# Altium schematic sheet transmitter.SchDoc
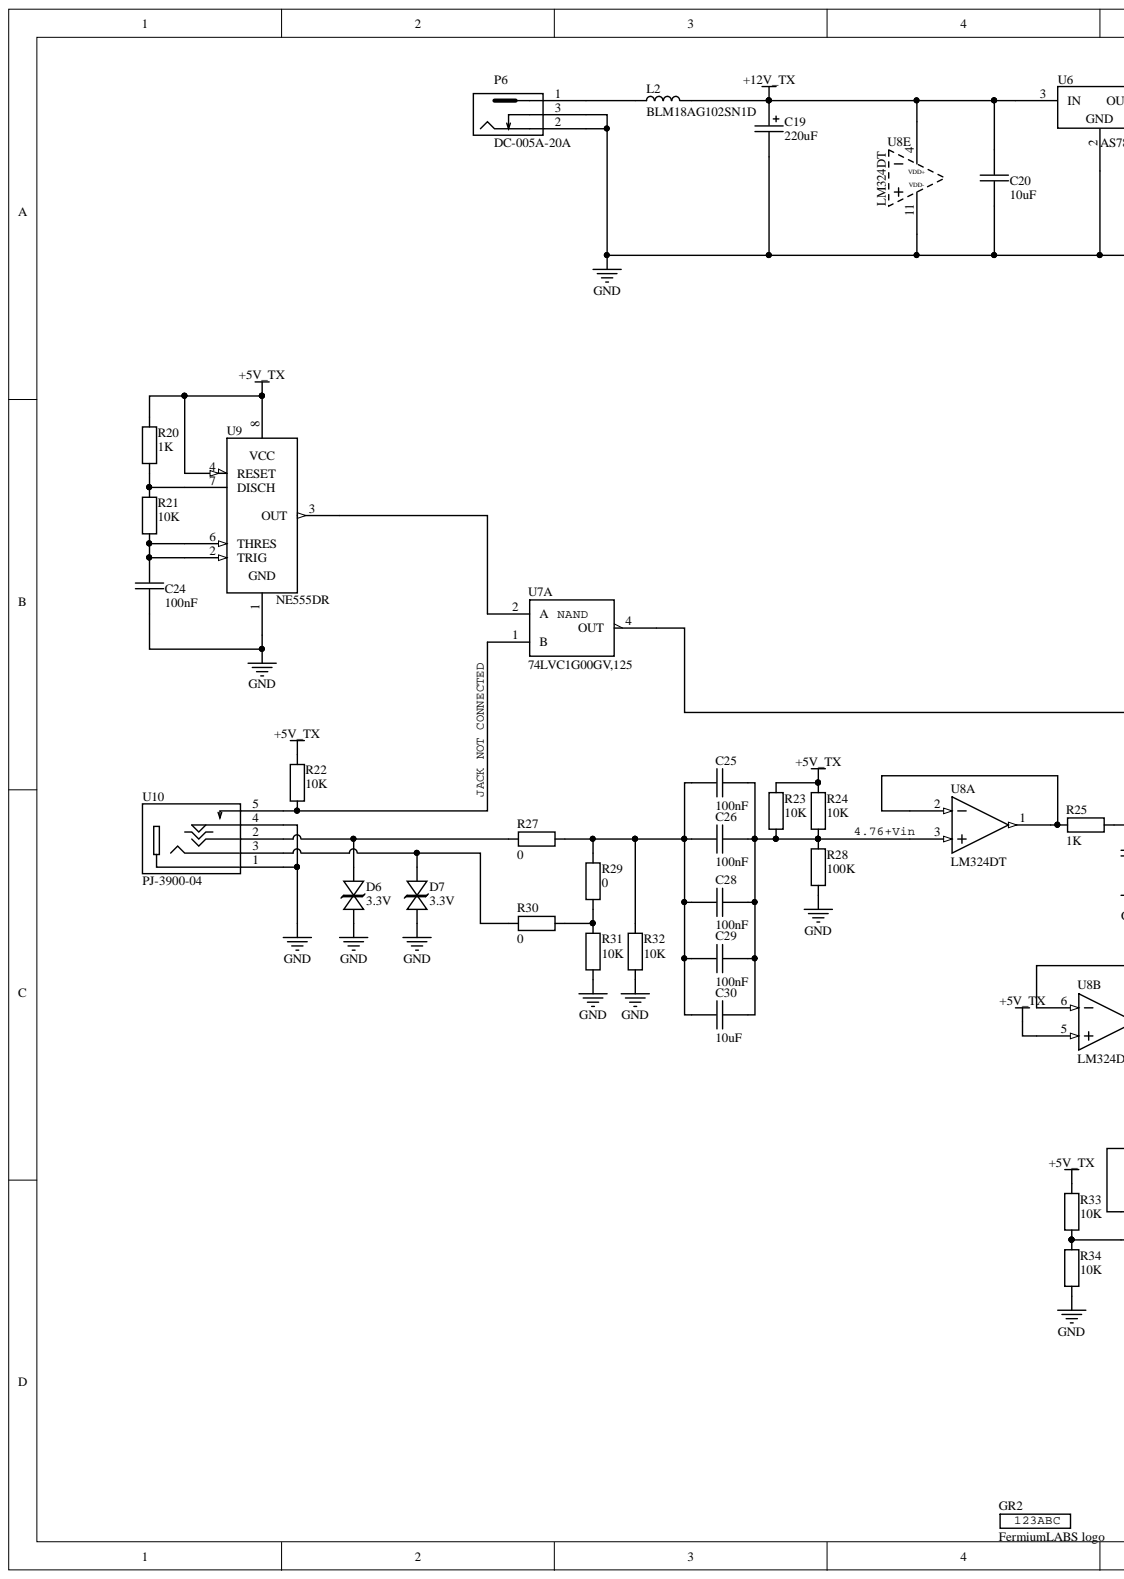
[diagram p0: transmitter.SchDoc, left]
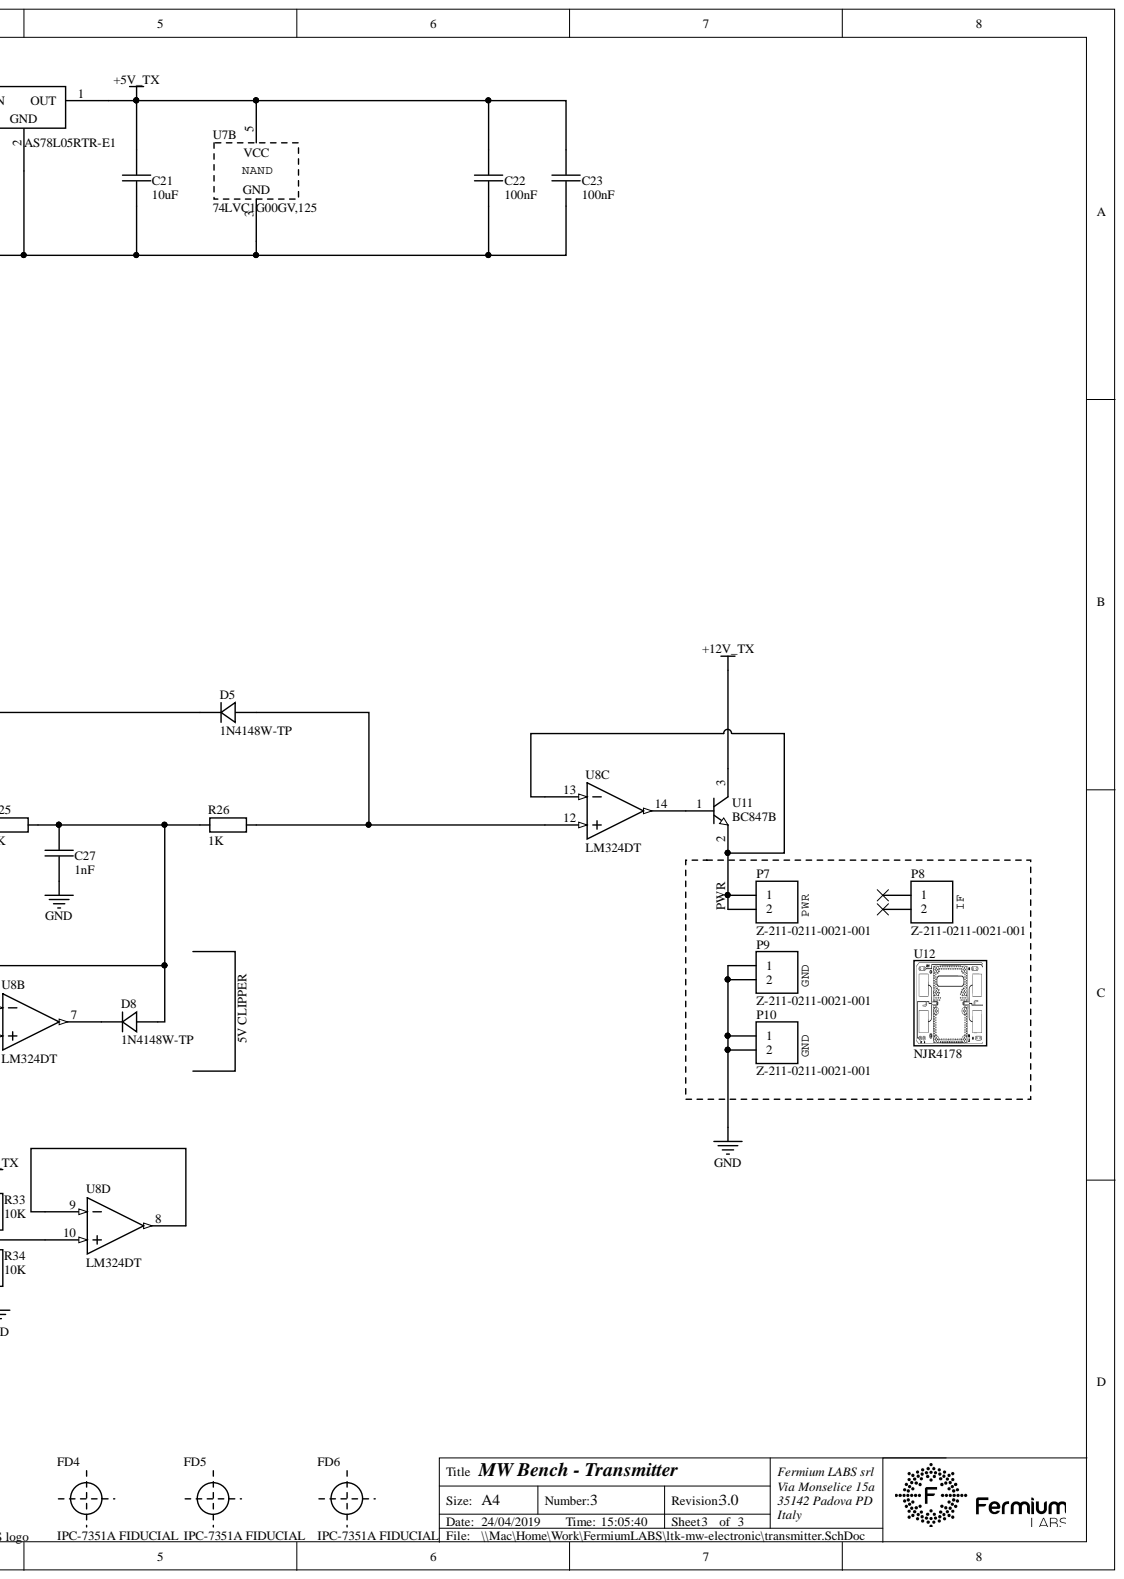
[diagram p1: transmitter.SchDoc, right]

## Parts
C19  220uF  [3924B366-8672-45E9-B0BE-62DACC24E783]
C20  10uF  [CAP 0805_2012]
C21  10uF  [CAP 0805_2012]
C22  100nF  [CAP 0603_1608]
C23  100nF  [CAP 0603_1608]
C24  100nF  [CAP 0603_1608]
C25  100nF  [CAP 0603_1608]
C26  100nF  [CAP 0603_1608]
C27  1nF  [CAP 0603_1608]
C28  100nF  [CAP 0603_1608]
C29  100nF  [CAP 0603_1608]
C30  10uF  [CAP 0805_2012]
D5  1N4148W-TP  [SOD-123]
D6  3.3V  [SOD523F]
D7  3.3V  [SOD523F]
D8  1N4148W-TP  [SOD-123]
FD4  IPC-7351A FIDUCIAL  [FIDUCIAL-IPC-7351A]  (no BOM)
FD5  IPC-7351A FIDUCIAL  [FIDUCIAL-IPC-7351A]  (no BOM)
FD6  IPC-7351A FIDUCIAL  [FIDUCIAL-IPC-7351A]  (no BOM)
GR2  FermiumLABS logo  [5394DE47-A0E8-4329-8464-7791B7C19310]  (no BOM)
L2  BLM18AG102SN1D  [FER 0603_1608]
P6  DC-005A-20A  [F0972D8A-C5C0-4A84-836D-A9666EE65C2D]
P7  Z-211-0211-0021-001  [0FBEB40D-2B6D-43C7-945F-A2D40A82B953]
P8  Z-211-0211-0021-001  [0FBEB40D-2B6D-43C7-945F-A2D40A82B953]
P9  Z-211-0211-0021-001  [0FBEB40D-2B6D-43C7-945F-A2D40A82B953]
P10  Z-211-0211-0021-001  [0FBEB40D-2B6D-43C7-945F-A2D40A82B953]
R20  1K  [RES 0603_1608]
R21  10K  [RES 0603_1608]
R22  10K  [RES 0603_1608]
R23  10K  [RES 0603_1608]
R24  10K  [RES 0603_1608]
R25  1K  [RES 0603_1608]
R26  1K  [RES 0603_1608]
R27  0  [RES 0603_1608]
R28  100K  [RES 0603_1608]
R29  0  [RES 0603_1608]
R30  0  [RES 0603_1608]
R31  10K  [RES 0603_1608]
R32  10K  [RES 0603_1608]
R33  10K  [RES 0603_1608]
R34  10K  [RES 0603_1608]
U6  AS78L05RTR-E1  [E733EFB9-FE91-4AE9-9197-29251971C3DC]
U7  74LVC1G00GV,125  [9B4F62E8-D129-4139-99C7-3E5580D0C4B3]  (parts A,B)
U8  LM324DT  [34355DA5-B1DA-47C2-A88A-ECF82120A4F7]  (parts A,B,C,D,E)
U9  NE555DR  [TI SOIC-8 D]
U10  PJ-3900-04  [7EF2B92C-0FC9-4C85-B662-5CEC65BFCCC4]
U11  BC847B  [TI SOT-23-3 DBZ]
U12  NJR4178  [25B19271-CD6F-4BC8-A99B-F617D566DC9C]

## Nets
+5V_TX: C21.2 C22.2 C23.2 R20.2 R22.1 R23.2 R24.2 R33.2 U6.1 U7.5 U8.5 U9.4(RESET) U9.8
+12V_TX: C19.1 C20.2 L2.2 U6.3 U8.4 U11.3
GND: C19.2 C20.1 C21.1 C22.1 C23.1 C24.2 C27.2 D6.1 D7.1 P6.2 P6.3 P9.1 P9.2 P10.1 P10.2 R28.1 R31.1 R32.1 R34.1 U6.2 U7.3 U8.11 U9.1 U10.1 U10.4
PWR: P7.1 P7.2 U8.13 U11.2
NetC24_1: C24.1 R21.1 U9.2(TRIG) U9.6(THRES)
NetC25_1: C25.1 C26.1 C28.1 C29.1 C30.1 R27.1 R29.1 R32.2
NetC25_2: C25.2 C26.2 C28.2 C29.2 C30.2 R23.1 R24.1 R28.2 U8.3
NetC27_1: C27.1 D8.1 R25.2 R26.1 U8.6
NetD5_1: D5.1 R26.2 U8.12
NetD5_2: D5.2 U7.4(OUT)
NetD6_2: D6.2 R27.2 U10.2
NetD7_2: D7.2 R30.2 U10.3
NetD8_2: D8.2 U8.7
NetL2_1: L2.1 P6.1
NetR20_1: R20.1 R21.2 U9.7(DISCH)
NetR22_2: R22.2 U7.1(B) U10.5
NetR25_1: R25.1 U8.1 U8.2
NetR29_2: R29.2 R30.1 R31.2
NetR33_1: R33.1 R34.2 U8.10
NetU7_2: U7.2(A) U9.3(OUT)
NetU8_8: U8.8 U8.9
NetU8_14: U8.14 U11.1

Not connected: P8 1 2

## Library data of the parts
C19 = 1a28df19-6d3e-4a71-836c-f22920f8e8ab: CAP ELECTROLYTIC THT 220uF 25V 20%; Manufacturer 1: Rubycon; Manufacturer Part Number 1: 25PK220MEFC-6.3*11; Database Table Name: public.fermiumlabs_all_pivoted; id: 14782; Component Kind: Standard; ComponentLink1Description: Datasheet; ComponentLink1URL: http://localhost:8080/static/files/Rubycon-220uF-25V_C109337_12019-03-10_214953.757848.pdf?Rubycon-220uF-25V_C109337 (1).pdf; Part Number: 1a28df19-6d3e-4a71-836c-f22920f8e8ab; Value: 220uF; Library Name: frmdblib.DbLib
C20 C21 C30 = 1ffbe5a9-0884-4740-bdad-20a6a98400e6: CAP SMD CHIP 10uF 25V 0805 X5R; Manufacturer 1: Samsung Electro-Mechanics; Manufacturer Part Number 1: CL21A106KAYNNNE; Database Table Name: public.fermiumlabs_all_pivoted; id: 14779; Component Kind: Standard; ComponentLink1Description: Datasheet; ComponentLink1URL: http://localhost:8080/static/files/Samsung-Electro-Mechanics-CL21A106KAYNNNE_C158502019-03-10_213827.610739.pdf?Samsung-Electro-Mechanics-CL21A106KAYNNNE_C15850.pdf; Part Number: 1ffbe5a9-0884-4740-bdad-20a6a98400e6; Value: 10uF; Library Name: frmdblib.DbLib
C22-C26 C28 C29 = 02841f84-ac15-41b5-9e17-915f8f067828: CAP SMD CHIP 100nF 25V 0603 X7R; Manufacturer 1: Samsung Electro-Mechanics; Manufacturer Part Number 1: CL10B104KA8NNNC; Database Table Name: public.fermiumlabs_all_pivoted; id: 14784; Component Kind: Standard; ComponentLink1Description: Datasheet; ComponentLink1URL: http://localhost:8080/static/files/Samsung-Electro-Mechanics-CL10B104KA8NNNC_C15902019-03-10_215548.826887.pdf?Samsung-Electro-Mechanics-CL10B104KA8NNNC_C1590.pdf; Part Number: 02841f84-ac15-41b5-9e17-915f8f067828; Value: 100nF; Library Name: frmdblib.DbLib
C27 = 0eca2548-3630-4fea-a3d5-94248c1cae74: CAP SMD CHIP 1nF 50V 0603 X7R; Manufacturer 1: Samsung Electro-Mechanics; Manufacturer Part Number 1: CL10B102KB8NNNC; Database Table Name: public.fermiumlabs_all_pivoted; id: 14785; Component Kind: Standard; ComponentLink1Description: Datasheet; ComponentLink1URL: http://localhost:8080/static/files/Samsung-Electro-Mechanics-CL10B102KB8NNNC_C1588_12019-03-10_215732.131818.pdf?Samsung-Electro-Mechanics-CL10B102KB8NNNC_C1588 (1).pdf; Part Number: 0eca2548-3630-4fea-a3d5-94248c1cae74; Value: 1nF; Library Name: frmdblib.DbLib
D5 D8 = 5e920700-75df-48e3-84dd-8f9f777caed8: DIODE SMD 100V 150mA 1.5Vf SOD-123 4148; Manufacturer 1: MCC; Database Table Name: public.fermiumlabs_all_pivoted; id: 14795; Component Kind: Standard; ComponentLink1Description: Datasheet; ComponentLink1URL: http://localhost:8080/static/files/Micro-Commercial-Components-MCC-1N4148W-TP_C779782019-04-06_215019.428643.pdf?Micro-Commercial-Components-MCC-1N4148W-TP_C77978.pdf; Manufacturer Part Number 1: 1N4148W-TP; Part Number: 5e920700-75df-48e3-84dd-8f9f777caed8; Library Name: frmdblib.DbLib
D6 D7 = d6266fd0-7428-4fb9-a062-338cdce926fd: DIODE TVS 3.3V BIDIRECTIONAL SOD-523; Manufacturer 1: Born Semiconductor; Manufacturer Part Number 1: PESD3V3S1BA-N; Database Table Name: public.fermiumlabs_all_pivoted; id: 14798; Component Kind: Standard; ComponentLink1Description: Datasheet; ComponentLink1URL: http://localhost:8080/static/files/Bourne-Semicon-Shenzhen-PESD3V3S1BA-N_C3160372019-04-07_002431.794112.pdf?Bourne-Semicon-Shenzhen-PESD3V3S1BA-N_C316037.pdf; Part Number: d6266fd0-7428-4fb9-a062-338cdce926fd; Value: 3.3V; Library Name: frmdblib.DbLib
FD4-FD6 = 19da4612-eb95-43bb-9984-553a52b2a224: GRAPHICS FIDUCIAL IPC-7351A; Database Table Name: public.fermiumlabs_all_pivoted; id: 14800; Part Number: 19da4612-eb95-43bb-9984-553a52b2a224; Library Name: frmdblib.DbLib
GR2 = 50f8ea88-0034-49fe-a263-d8e97bc35e2f: GRAPHICS LOGO FERMIUM LABS SRL; Database Table Name: public.fermiumlabs_all_pivoted; id: 14799; Part Number: 50f8ea88-0034-49fe-a263-d8e97bc35e2f; Library Name: frmdblib.DbLib
L2 = c9e30ae8-9d5b-4135-a079-b1147da846d8: FER SMD CHIP 0603 400mA 1k@100MHz 500mOhm; Manufacturer 1: Murata; Database Table Name: public.fermiumlabs_all_pivoted; id: 14786; Component Kind: Standard; ComponentLink1Description: Datasheet; ComponentLink1URL: http://localhost:8080/static/files/Murata-Electronics-BLM18AG102SN1D_C858242019-03-10_220021.186607.pdf?Murata-Electronics-BLM18AG102SN1D_C85824.pdf; Manufacturer Part Number 1: BLM18AG102SN1D; Part Number: c9e30ae8-9d5b-4135-a079-b1147da846d8; Library Name: frmdblib.DbLib
P6 = b53db51a-1725-412b-9039-fa7a45c7c438: CONN DC JACK 0.5A 30VDC 5Kcycles; Manufacturer 1: HRO Electronics; Database Table Name: public.fermiumlabs_all_pivoted; id: 14780; Component Kind: Standard; ComponentLink1Description: Datasheet; ComponentLink1URL: http://localhost:8080/static/files/Korean-Hroparts-Elec-DC-005A-20A_C191049_22019-03-10_214231.486078.pdf?Korean-Hroparts-Elec-DC-005A-20A_C191049 (2).pdf; Manufacturer Part Number 1: DC-005A-20A; Part Number: b53db51a-1725-412b-9039-fa7a45c7c438; Library Name: frmdblib.DbLib
P7-P10 = fb9043ef-1dd5-4a9c-8c1a-da0c667690ac: CONN PIN HEADER 2P MALE 2.54mm; Manufacturer 1: Nextronics Engineering; Database Table Name: public.fermiumlabs_all_pivoted; id: 14796; Component Kind: Standard; ComponentLink1Description: Datasheet; ComponentLink1URL: http://localhost:8080/static/files/Shenzhen-Cankemeng-Headers-Pins-1-2P-2-54mm-Straight-line_C1243752019-04-06_220043.339618.pdf?Shenzhen-Cankemeng-Headers-Pins-1-2P-2-54mm-Straight-line_C124375.pdf; ComponentLink2Description: Datasheet; ComponentLink2URL: http://localhost:8080/static/files/Nextronics-Engineering-Z-211-0211-0021-001_C1521542019-04-06_220050.159477.pdf?Nextronics-Engineering-Z-211-0211-0021-001_C152154.pdf; ComponentLink3Description: Datasheet; ComponentLink3URL: http://localhost:8080/static/files/Changjiang-Connectors-A2541WV-2P_C2254772019-04-06_220058.382973.pdf?Changjiang-Connectors-A2541WV-2P_C225477.pdf; Manufacturer 2: Changjiang Connectors; Manufacturer 3: Shenzhen Cankemeng; Manufacturer Part Number 1: Z-211-0211-0021-001; Manufacturer Part Number 2: A2541WV-2P; Manufacturer Part Number 3: ---; Part Number: fb9043ef-1dd5-4a9c-8c1a-da0c667690ac; Library Name: frmdblib.DbLib
R20 R25 R26 = a678220f-9e9d-4863-a833-9993504f723c: RES SMD 1K OHM 1% 1/10W 0603; Manufacturer 1: Yageo; Manufacturer Part Number 1: RC0603FR-071KL; Database Table Name: public.fermiumlabs_all_pivoted; id: 14794; Component Kind: Standard; Part Number: a678220f-9e9d-4863-a833-9993504f723c; Value: 1K; Library Name: frmdblib.DbLib
R21-R24 R31-R34 = 93242766-ba1d-462d-b58f-ce1dfc4129c8: RES SMD 10K OHM 1% 1/10W 0603; Manufacturer 1: Yageo; Manufacturer Part Number 1: RC0603FR-0710KL; Database Table Name: public.fermiumlabs_all_pivoted; id: 12101; Component Kind: Standard; Part Number: 93242766-ba1d-462d-b58f-ce1dfc4129c8; Value: 10K; Library Name: frmdblib.DbLib
R27 R29 R30 = 6ae227f9-847f-4766-8522-e11a83531204: RES SMD 0 OHM 5% 1/10W 0603; Manufacturer 1: Yageo; Manufacturer Part Number 1: RC0603JR-070RL; Database Table Name: public.fermiumlabs_all_pivoted; id: 11973; Component Kind: Standard; Part Number: 6ae227f9-847f-4766-8522-e11a83531204; Value: 0; Library Name: frmdblib.DbLib
R28 = 76af10b3-9d85-4b5c-a2d6-26cc74f432f7: RES SMD 100K OHM 1% 1/10W 0603; Manufacturer 1: Yageo; Manufacturer Part Number 1: RC0603FR-07100KL; Database Table Name: public.fermiumlabs_all_pivoted; id: 12746; Component Kind: Standard; Part Number: 76af10b3-9d85-4b5c-a2d6-26cc74f432f7; Value: 100K; Library Name: frmdblib.DbLib
U6 = fd64863e-487f-4688-b264-696c0ba5456b: IC LINEAR VOLTAGE REGULATOR 5V 100mA; Manufacturer 1: Diodes Inc.; Database Table Name: public.fermiumlabs_all_pivoted; id: 14783; Component Kind: Standard; ComponentLink1Description: Datasheet; ComponentLink1URL: http://localhost:8080/static/files/Diodes-Incorporated-AS78L05RTR-E1_C90471_12019-03-10_215252.941962.pdf?Diodes-Incorporated-AS78L05RTR-E1_C90471 (1).pdf; ComponentLink2Description: Datasheet; ComponentLink2URL: http://localhost:8080/static/files/KIA-Semicon-Tech-KIA78L05T_C90763_12019-03-10_215415.523371.pdf?KIA-Semicon-Tech-KIA78L05T_C90763 (1).pdf; Manufacturer 2: KIA Semicon Tech; Manufacturer Part Number 1: AS78L05RTR-E1; Manufacturer Part Number 2: KIA78L05T; Part Number: fd64863e-487f-4688-b264-696c0ba5456b; Library Name: frmdblib.DbLib
U7 = 33900555-dbb4-4b72-871f-952983a59b08: IC NAND GATE 2 INPUTS 74X00 SC-74A; Manufacturer 1: Nexperia; Database Table Name: public.fermiumlabs_all_pivoted; id: 14797; Component Kind: Standard; ComponentLink1Description: Datasheet; ComponentLink1URL: http://localhost:8080/static/files/Nexperia-74LVC1G00GV-125_C1313082019-04-06_222642.812294.pdf?Nexperia-74LVC1G00GV-125_C131308.pdf; Manufacturer Part Number 1: 74LVC1G00GV,125; Part Number: 33900555-dbb4-4b72-871f-952983a59b08; Library Name: frmdblib.DbLib
U8 = 21a85167-c328-42f7-a52e-365842c6dad3: IC OPAMP LM324DT SO-14; Manufacturer 1: ST Microelectronics; Database Table Name: public.fermiumlabs_all_pivoted; id: 14792; Component Kind: Standard; ComponentLink1Description: Datasheet; ComponentLink1URL: http://localhost:8080/static/files/STMicroelectronics-LM324DT_C710352019-04-06_191500.254110.pdf?STMicroelectronics-LM324DT_C71035.pdf; Manufacturer Part Number 1: LM324DT; Part Number: 21a85167-c328-42f7-a52e-365842c6dad3; Library Name: frmdblib.DbLib
U9 = d16ab88a-2543-469a-8940-7b800b633548: IC TIMER NE555 SOIC-8; Manufacturer 1: Texas Instruments; Database Table Name: public.fermiumlabs_all_pivoted; id: 14787; Component Kind: Standard; ComponentLink1Description: Datasheet; ComponentLink1URL: http://localhost:8080/static/files/Texas-Instruments-TI-NE555DR_C7593_22019-03-10_221020.033506.pdf?Texas-Instruments-TI-NE555DR_C7593 (2).pdf; Manufacturer Part Number 1: NE555DR; Part Number: d16ab88a-2543-469a-8940-7b800b633548; Library Name: frmdblib.DbLib
U10 = 80507a24-5d7c-4cf5-953e-f54832d54564: CONN 3.5mm AUDIO STEREO AUX SWITCH; Manufacturer 1: HRO Electronics; Database Table Name: public.fermiumlabs_all_pivoted; id: 14793; Component Kind: Standard; ComponentLink1Description: Datasheet; ComponentLink1URL: http://localhost:8080/static/files/Korean-Hroparts-Elec-PJ-3900-04_C1518392019-04-10_231144.687617.pdf?Korean-Hroparts-Elec-PJ-3900-04_C151839.pdf; Manufacturer Part Number 1: PJ-3900-04; Part Number: 80507a24-5d7c-4cf5-953e-f54832d54564; Library Name: frmdblib.DbLib
U11 = 518be146-f87c-4403-9352-4982c61a9251: TRANS NPN BC847 100mA 40V 220mW SOT-23-3; Manufacturer 1: Nexperia; Database Table Name: public.fermiumlabs_all_pivoted; id: 14791; Component Kind: Standard; ComponentLink1Description: Datasheet; ComponentLink1URL: http://localhost:8080/static/files/Nexperia-BC847B-215_C57668_32019-03-11_205443.293175.pdf?Nexperia-BC847B-215_C57668 (3).pdf; Manufacturer Part Number 1: BC847B; Part Number: 518be146-f87c-4403-9352-4982c61a9251; Library Name: frmdblib.DbLib
U12 = f0e19a38-18de-4253-8da2-aebb0c4083bd: MODULE RADAR DOPPLER 10.525GHz NJR4178; Manufacturer 1: New Japan Radio; Database Table Name: public.fermiumlabs_all_pivoted; id: 14789; Component Kind: Standard; ComponentLink1Description: Datasheet; ComponentLink1URL: http://localhost:8080/static/files/Xdoppler_NJR41767881_rev032019-03-10_230533.619662.pdf?Xdoppler_NJR4176^78^81_rev03.pdf; ComponentLink2Description: Corrispondence; ComponentLink2URL: http://localhost:8080/static/files/FERMIUM_LABS_Moduli_NJR41782019-03-10_230730.901712.pdf?FERMIUM LABS  Moduli NJR4178.pdf; ComponentLink3Description: Quote; ComponentLink3URL: http://localhost:8080/static/files/201812111056099982019-03-10_230743.103809.pdf?20181211105609998.pdf; ComponentLink4Description: Product Page; ComponentLink4URL: https://micro.njr.com/eng/products/sensor/doppler/r4178.html; Manufacturer Part Number 1: NJR4178; Part Number: f0e19a38-18de-4253-8da2-aebb0c4083bd; Library Name: frmdblib.DbLib
